# Altium schematic sheet SignalNT.SchDoc
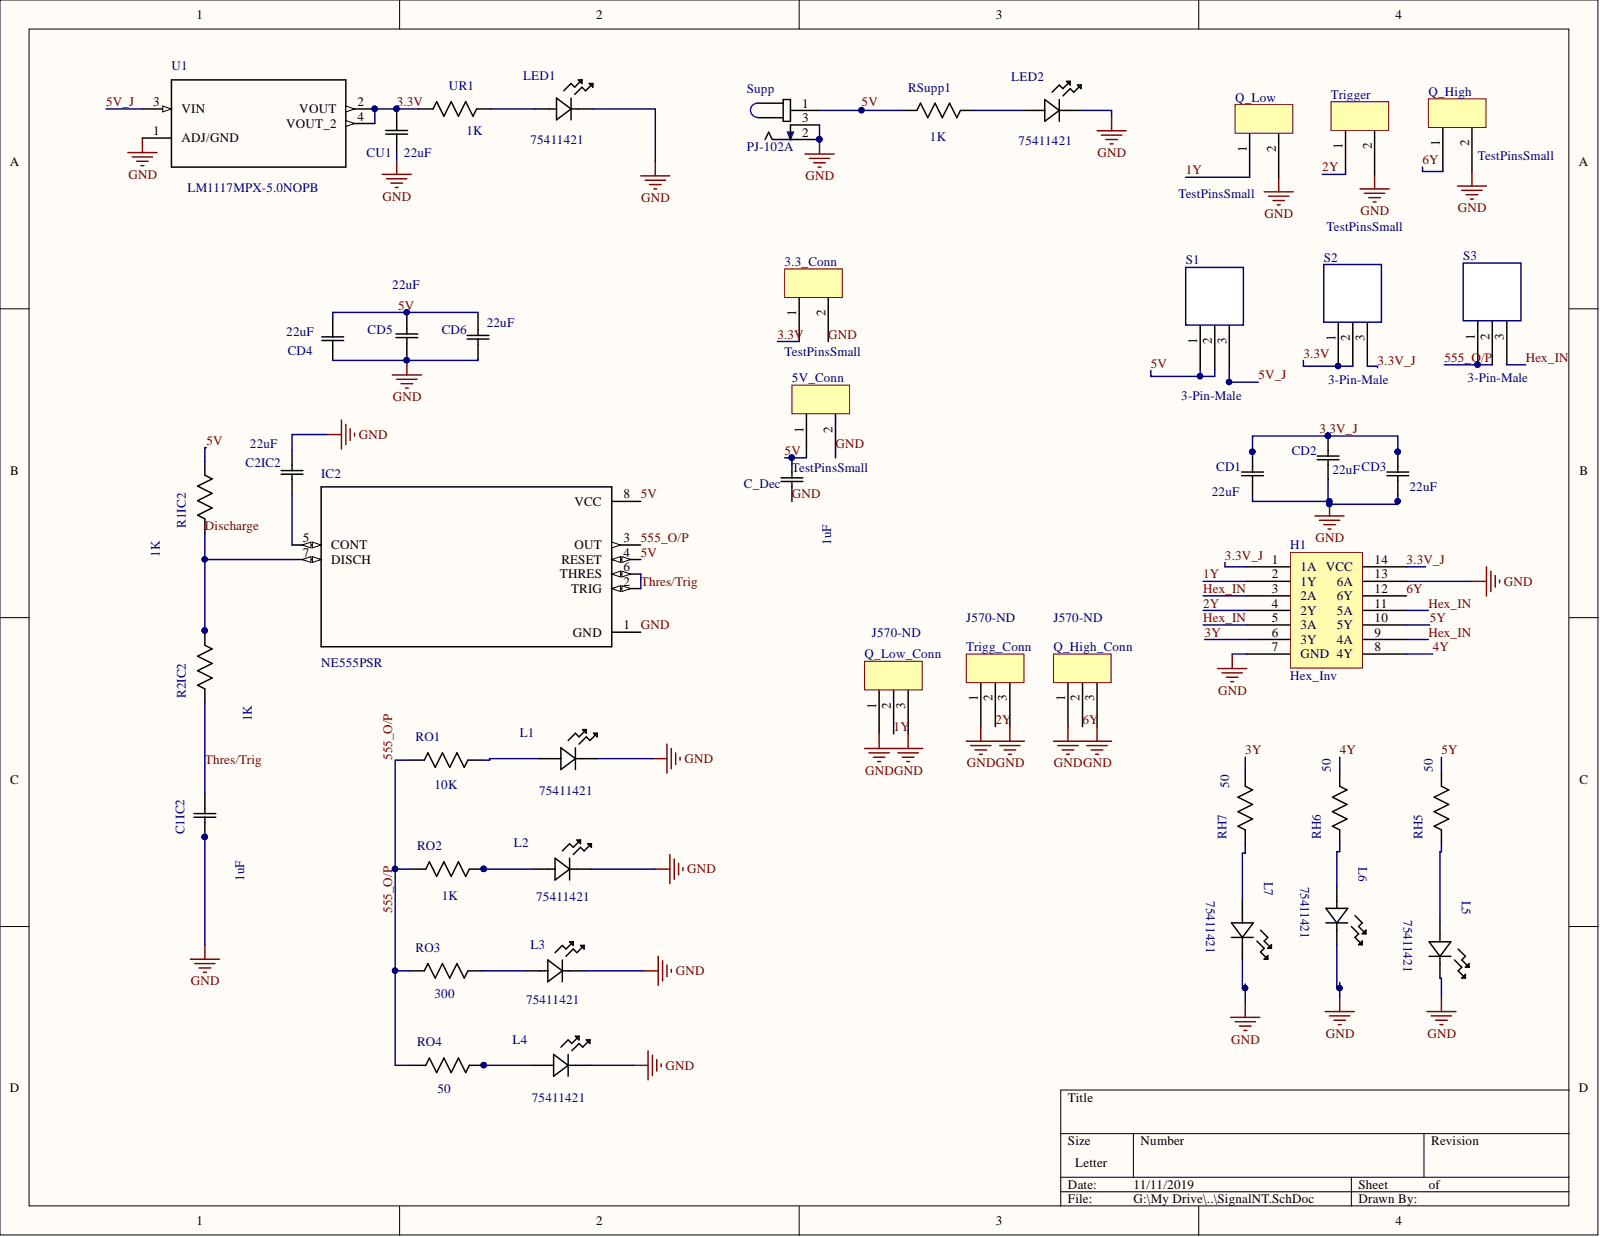
[diagram p0: SignalNT.SchDoc]

## Parts
3.3_Conn  TestPinsSmall  [PCBComponent_1]
5V_Conn  TestPinsSmall  [PCBComponent_1]
C1IC2  1uF  [CAPC3216X94N]
C2IC2  22uF  [CAPC3216X94N]
C_Dec  1uF  [CAPC3216X94N]
CD1  22uF  [CAPC3216X94N]
CD2  22uF  [CAPC3216X94N]
CD3  22uF  [CAPC3216X94N]
CD4  22uF  [CAPC3216X94N]
CD5  22uF  [CAPC3216X94N]
CD6  22uF  [CAPC3216X94N]
CU1  22uF  [CAPC3216X94N]
H1  Hex_Inv  [SOIC127P780X200-14N]
IC2  NE555PSR  [SOIC127P600X175-8N_NE555PSR(Primary)]
L1  75411421  [LED_597-3111-407F]
L2  75411421  [LED_597-3111-407F]
L3  75411421  [LED_597-3111-407F]
L4  75411421  [LED_597-3111-407F]
L5  75411421  [LED_597-3111-407F]
L6  75411421  [LED_597-3111-407F]
L7  75411421  [LED_597-3111-407F]
LED1  75411421  [LED_597-3111-407F]
LED2  75411421  [LED_597-3111-407F]
Q_High  TestPinsSmall  [PCBComponent_1]
Q_High_Conn  J570-ND  [J570-ND]
Q_Low  TestPinsSmall  [PCBComponent_1]
Q_Low_Conn  J570-ND  [J570-ND]
R1IC2  1K  [RESC3216X71N]
R2IC2  1K  [RESC3216X71N]
RH5  50  [RESC3216X71N]
RH6  50  [RESC3216X71N]
RH7  50  [RESC3216X71N]
RO1  10K  [RESC3216X71N]
RO2  1K  [RESC3216X71N]
RO3  300  [RESC3216X71N]
RO4  50  [RESC3216X71N]
RSupp1  1K  [RESC3216X71N]
S1  3-Pin-Male  [MaleHeader3Pin]
S2  3-Pin-Male  [MaleHeader3Pin]
S3  3-Pin-Male  [MaleHeader3Pin]
Supp  PJ-102A  [CUI_PJ-102A]
Trigg_Conn  J570-ND  [J570-ND]
Trigger  TestPinsSmall  [PCBComponent_1]
U1  LM1117MPX-5.0NOPB  [SOT230P696X180-4N]
UR1  1K  [RESC3216X71N]

## Nets
1Y: H1.2(1Y) Q_Low.1 Q_Low_Conn.2
2Y: H1.4(2Y) Trigg_Conn.2 Trigger.1
3.3V: 3.3_Conn.1 CU1.2 S2.1 S2.2 U1.2(VOUT) U1.4(VOUT_2) UR1.2
3.3V_J: CD1.2 CD2.2 CD3.2 H1.1(1A) H1.14(VCC) S2.3
3Y: H1.6(3Y) RH7.1
4Y: H1.8(4Y) RH6.1
5V: 5V_Conn.1 C_Dec.2 CD4.2 CD5.2 CD6.2 IC2.4(RESET) IC2.8(VCC) R1IC2.1 RSupp1.2 S1.1 S1.2 Supp.1
5V_J: S1.3 U1.3(VIN)
5Y: H1.10(5Y) RH5.1
6Y: H1.12(6Y) Q_High.1 Q_High_Conn.2
555_O/P: IC2.3(OUT) RO1.2 RO2.2 RO3.2 RO4.2 S3.1 S3.2
Discharge: IC2.7(DISCH) R1IC2.2 R2IC2.1
GND: 3.3_Conn.2 C1IC2.1 C2IC2.2 C_Dec.1 CD1.1 CD2.1 CD3.1 CD4.1 CD5.1 CD6.1 CU1.1 H1.7(GND) H1.13(6A) IC2.1(GND) L1.1 L2.1 L3.1 L4.1 L5.1 L6.1 L7.1 LED1.1 LED2.1 Q_High.2 Q_High_Conn.1 Q_High_Conn.3 Q_Low.2 Q_Low_Conn.1 Q_Low_Conn.3 Supp.2 Supp.3 Trigg_Conn.1 Trigg_Conn.3 Trigger.2 U1.1(ADJ/GND)
Hex_IN: H1.3(2A) H1.5(3A) H1.9(4A) H1.11(5A) S3.3
Thres/Trig: C1IC2.2 IC2.2(TRIG) IC2.6(THRES) R2IC2.2
NetC2IC2_1: C2IC2.1 IC2.5(CONT)
NetL1_2: L1.2 RO1.1
NetL2_2: L2.2 RO2.1
NetL3_2: L3.2 RO3.1
NetL4_2: L4.2 RO4.1
NetL5_2: L5.2 RH5.2
NetL6_2: L6.2 RH6.2
NetL7_2: L7.2 RH7.2
NetLED1_2: LED1.2 UR1.1
NetLED2_2: LED2.2 RSupp1.1

Not connected: 5V_Conn 2

## Library data of the parts
C1IC2 C2IC2 C_Dec CD1-CD6 CU1 = 1206_Cap: 1206 FlEXITERM 1nF Ceramic Multilayer Cap, 100VDC, +125C, X7R Dielectric, +/-10% SMD; MF: AVX; MP: 12061C102KAZ2A; Availability: Unavailable; Component Kind: Standard; Library Name: ECEN5013LIB.IntLib; Package: 1206 AVX; Price: None; SnapEDA_Link: https://www.snapeda.com/parts/12061C102KAZ2A/AVX/view-part/?ref=snap
H1 = 296-28498-1-ND: Component Kind: Standard; Library Name: ECEN5013LIB.IntLib
IC2 = 296-6502-1-ND: Precision Timer, Dual, 100khz, Sop-8; MF: Texas Instruments; Component Kind: Standard; Digi-Key_Part_Number: 296-6502-1-ND; Digi-Key_Purchase_URL: https://www.digikey.com/product-detail/en/texas-instruments/NE555PSR/296-6502-1-ND/372492?utm_source=snapeda&utm_medium=aggregator&utm_campaign=symbol; Library Name: ECEN5013LIB.IntLib; MP: NE555PSR; Package: SO-8 Texas Instruments; SnapEDA_Link: https://www.snapeda.com/parts/NE555PSR/Texas%20Instruments/view-part/?ref=snap
L1-L7 LED1 LED2 = 754-1142-1-ND: LED RED CLEAR 1206 SMD; MF: Dialight; MP: 5973111407F; Availability: Unavailable; Component Kind: Standard; Library Name: ECEN5013LIB.IntLib; Package: 1206 Dialight; Price: None; SnapEDA_Link: https://www.snapeda.com/parts/5973111407F/Dialight/view-part/?ref=snap
R1IC2 R2IC2 RH5-RH7 RO1-RO4 RSupp1 UR1 = 1206_Res: Res Thick Film 3.2 x 1.6 mm 470 Ohm 5% 0.25W_1/4W_ 100ppm/ C Molded SMD Paper T/R; MF: Yageo; MP: RC1206JR-07470RL; Availability: Unavailable; Component Kind: Standard; Library Name: ECEN5013LIB.IntLib; Package: 1206 Yageo; Price: None; SnapEDA_Link: https://www.snapeda.com/parts/RC1206JR-07470RL/Yageo/view-part/?ref=snap
S1-S3 = S1012EC-03-ND: Component Kind: Standard; Library Name: ECEN5013LIB.IntLib
U1 = AZ1117CH-3.3TRG1DICT-ND: 800mA Low-Dropout Linear Regulator 4-SOT-223 0 to 125; MF: Texas Instruments; Availability: Unavailable; Component Kind: Standard; Library Name: ECEN5013LIB.IntLib; MP: LM1117MPX-5.0NOPB; Package: None; Price: None; SnapEDA_Link: https://www.snapeda.com/parts/LM1117MPX-5.0NOPB/Texas%20Instruments/view-part/?ref=snap
3.3_Conn 5V_Conn Q_High Q_Low Trigger = TestPinsSmall: Component Kind: Standard
  3.3_Conn 5V_Conn: Library Name: Integrated_Library.IntLib
  Q_High Q_Low Trigger: Library Name: ECEN5013LIB.IntLib
Q_High_Conn Q_Low_Conn Trigg_Conn = J570-ND: Component Kind: Standard; Library Name: ECEN5013S19_REV2.IntLib
Supp = PJ-102A: Component Kind: Standard; Library Name: ECEN5013LIB.IntLib
